# Altium schematic sheet sem02_sd_hold.SchDoc
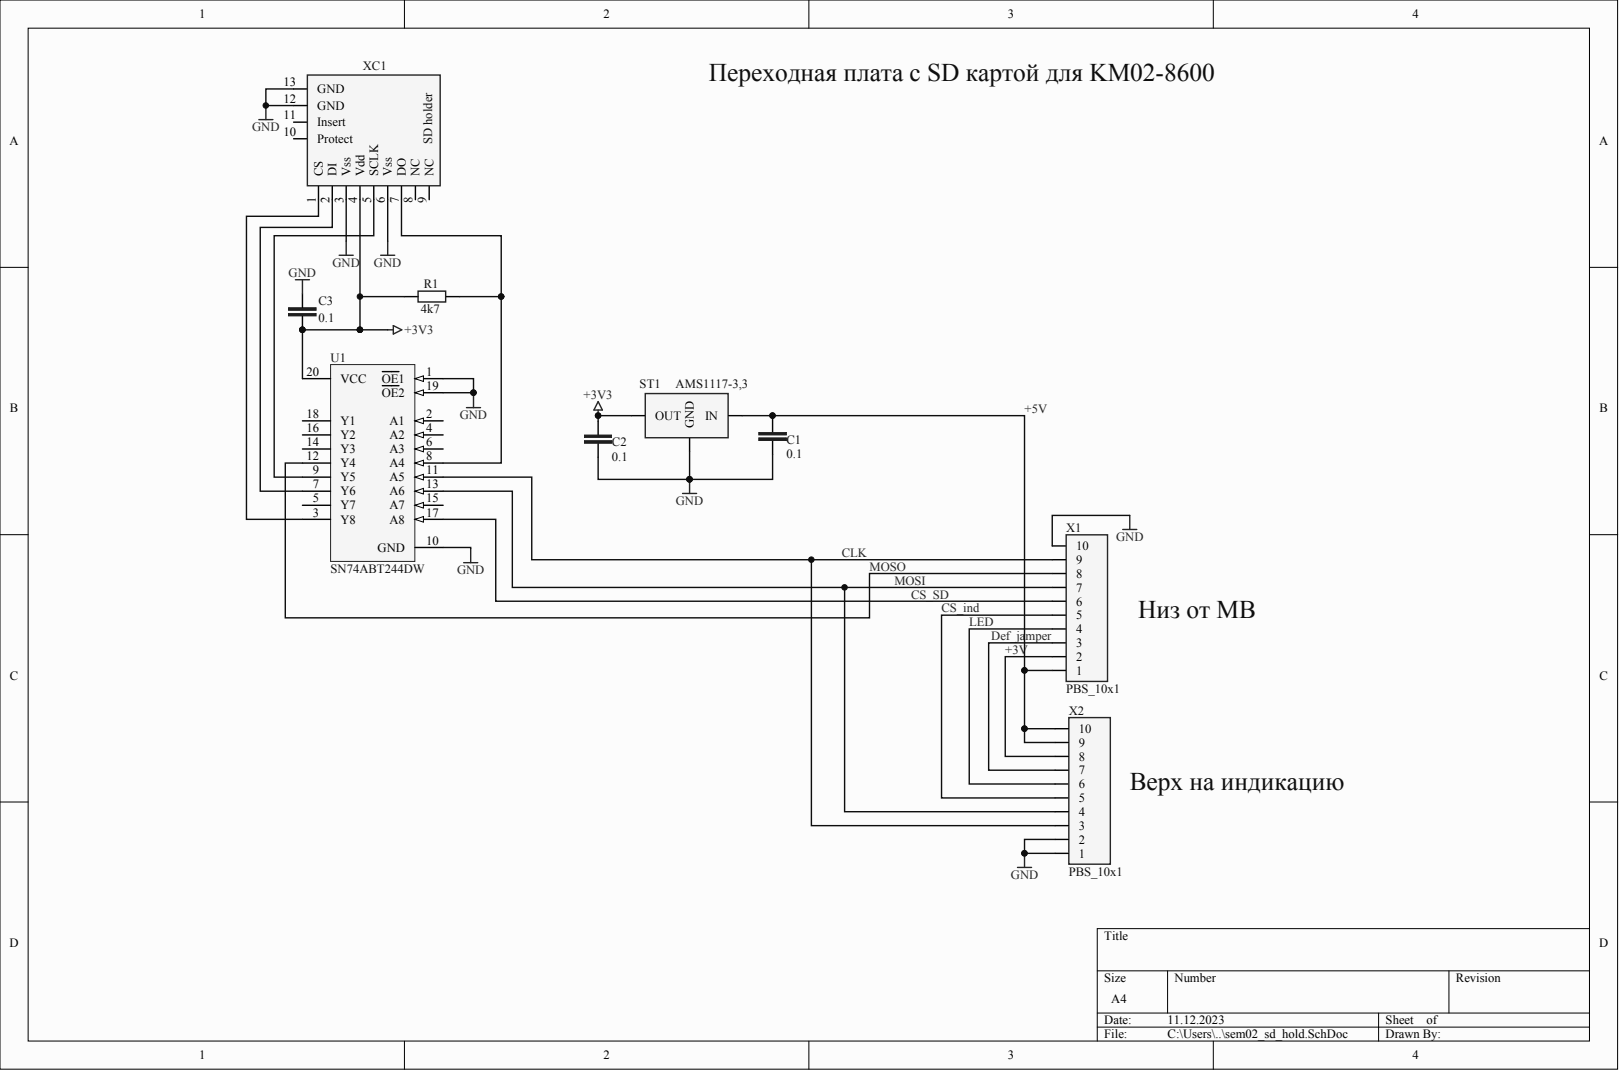
[diagram p0: sem02_sd_hold.SchDoc]

## Parts
C1  0.1
C2  0.1
C3  0.1
R1  4k7
ST1  AMS1117-3,3
U1  SN74ABT244DW
X1  PBS_10x1
X2  PBS_10x1
XC1  SD_card_holder  (value not drawn)

## Nets
+3V3: C2.1 C3.2 R1.2 ST1.2 U1.20(VCC) XC1.4(Vdd)
GND: C1.2 C2.2 C3.1 ST1.1 U1.1(OE1) U1.10(GND) U1.19(OE2) X1.10 X2.1 X2.2 XC1.3(Vss) XC1.6(Vss) XC1.12(GND) XC1.13(GND)
+3V: X1.2 X2.8
+5V: C1.1 ST1.3 X1.1 X2.9 X2.10
CLK: U1.11(A5) X1.9 X2.3
CS_IND: X1.5 X2.5
CS_SD: U1.17(A8) X1.6
DEF_JAMPER: X1.3 X2.7
LED: X1.4 X2.6
MOSI: U1.13(A6) X1.7 X2.4
MOSO: U1.12(Y4) X1.8
NetR1_1: R1.1 U1.8(A4) XC1.7(DO)
NetU1_3: U1.3(Y8) XC1.1(CS)
NetU1_7: U1.7(Y6) XC1.2(DI)
NetU1_9: U1.9(Y5) XC1.5(SCLK)

Not connected: U1 2(A1) 4(A2) 5(Y7) 6(A3) 14(Y3) 15(A7) 16(Y2) 18(Y1); XC1 8(NC) 9(NC) 10(Protect) 11(Insert)

## Library data of the parts
U1 = (no symbol name): ComponentAvailability: Obsolete; Published: 8-Jun-2000; LatestRevisionDate: 13-Apr-2006; LatestRevisionNote: IPC-7351 Footprint Added.; DatasheetVersion: Jul-1994; PackageVersion: Mar-2000; PackageReference: DW020; PackageDescription: 20-Pin Plastic Small Outline Integrated Circuit 1.27 mm Pitch; Code_JEDEC: MS-013; Code_IPC: SOIC127P1030-20; ComponentLink1Description: Manufacturer Link; ComponentLink1URL: http://www.ti.com/; ComponentLink2Description: Discontinuation Notice; ComponentLink2URL: http://focus.ti.com/docs/prod/folders/print/sn74abt244.html; Publisher: Altium Limited
